# Altium schematic sheet CDU_Logic.SchDoc(CDU_Logic)
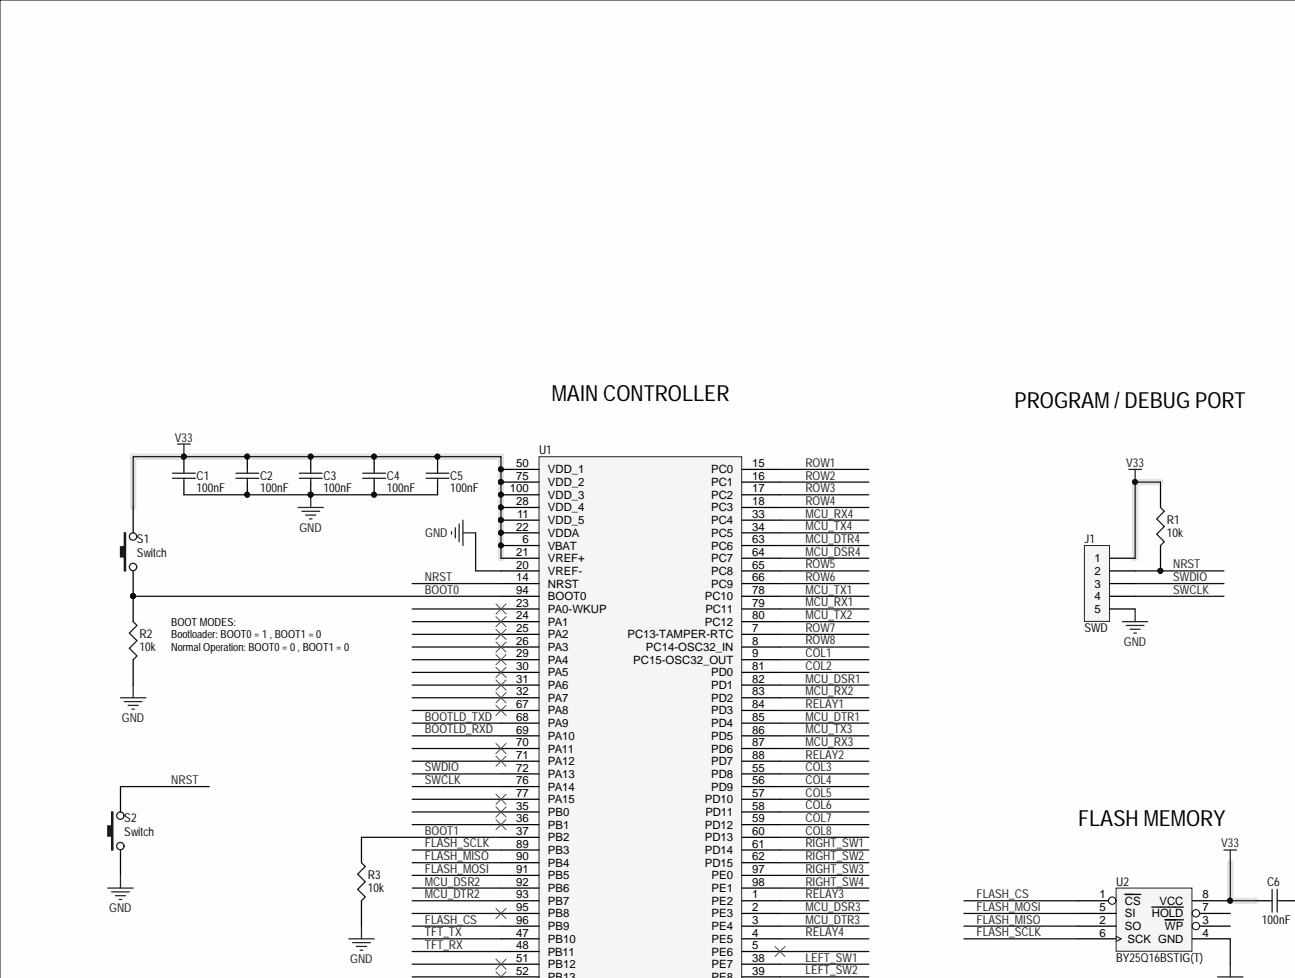
[diagram p0: CDU_Logic.SchDoc, top left]
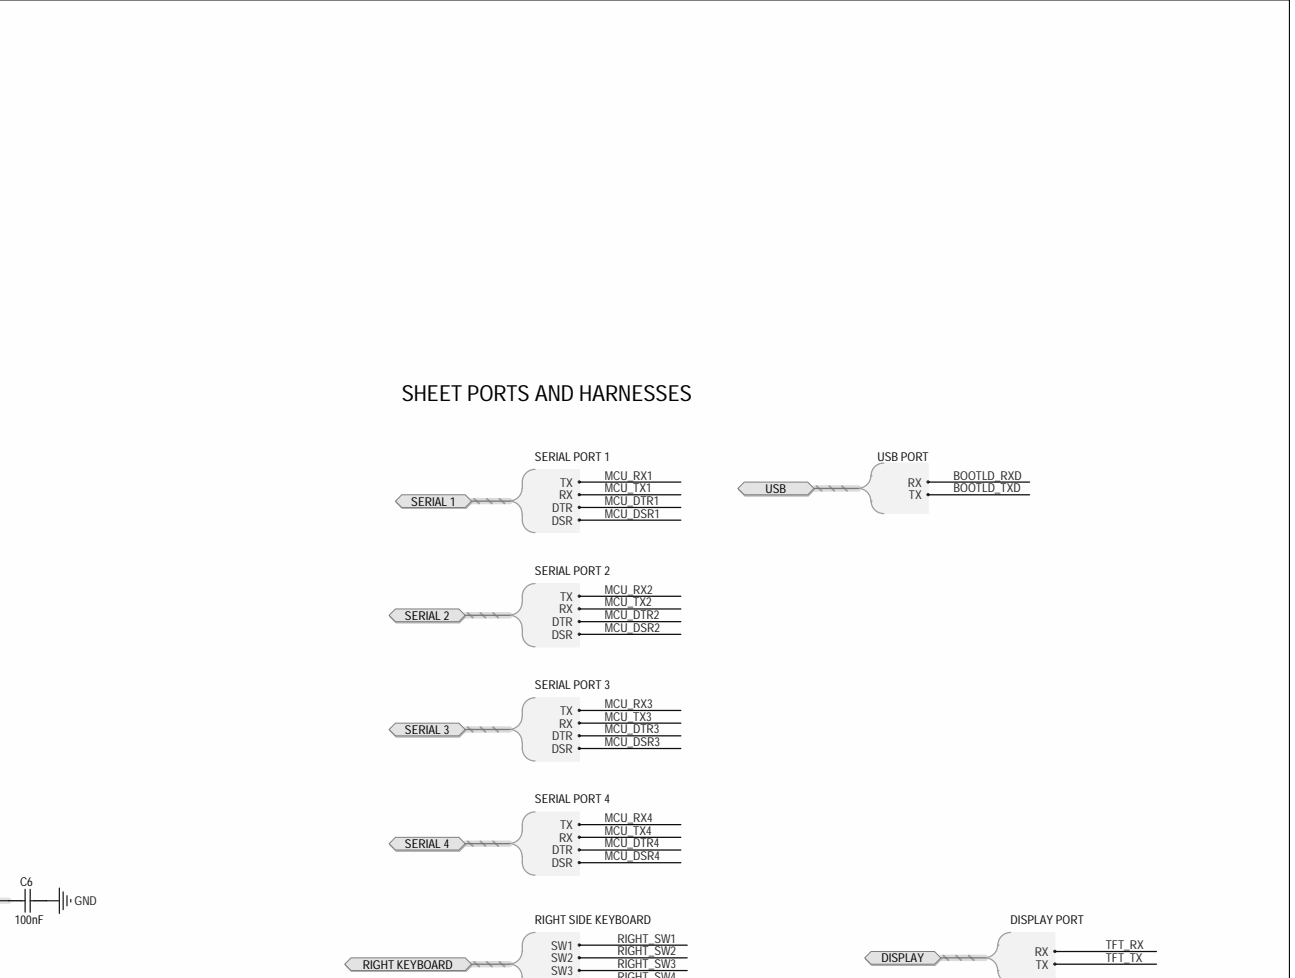
[diagram p1: CDU_Logic.SchDoc, top right]
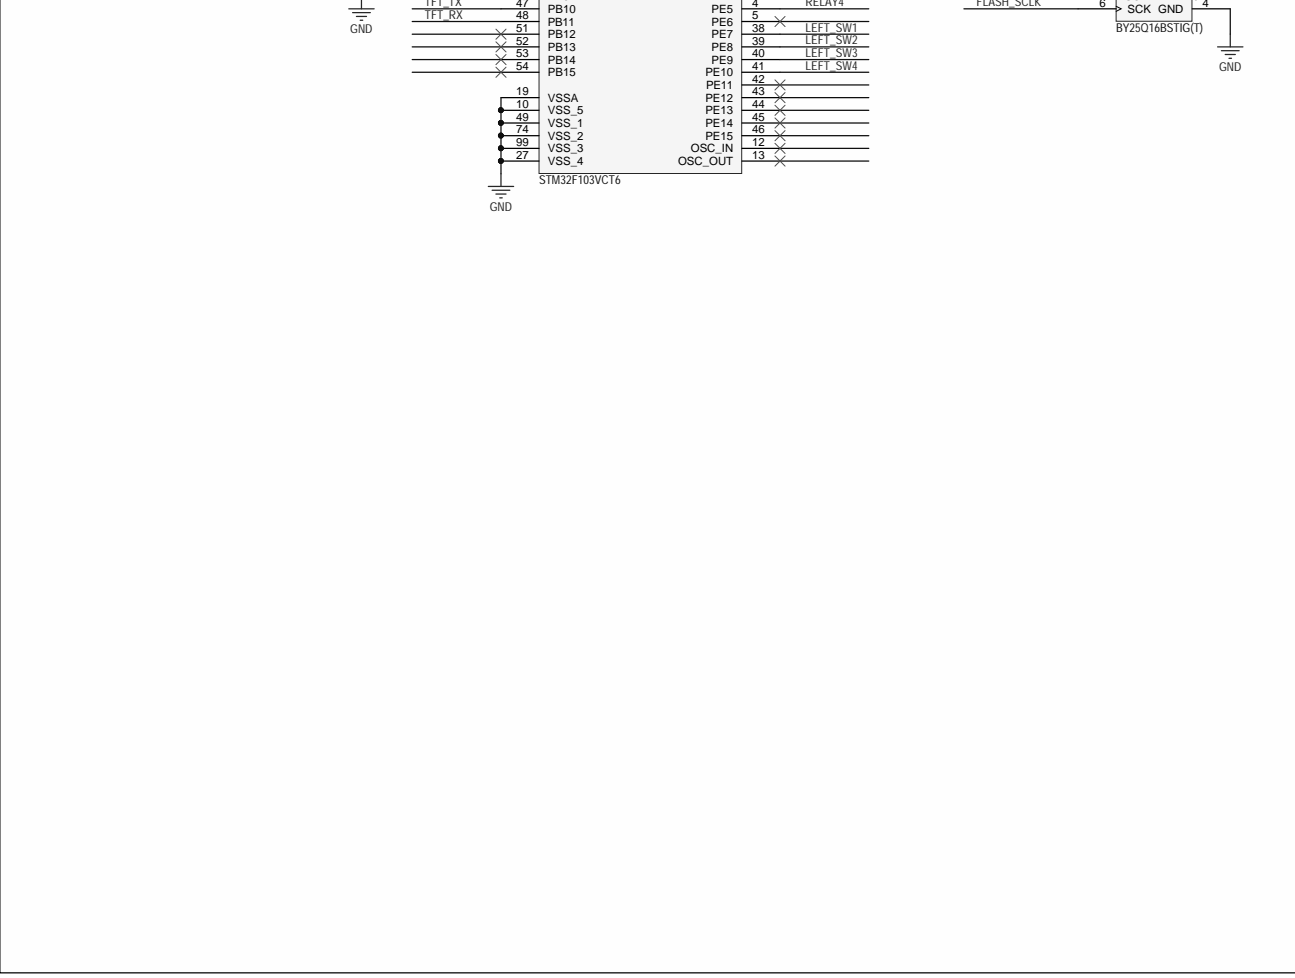
[diagram p2: CDU_Logic.SchDoc, bottom left]
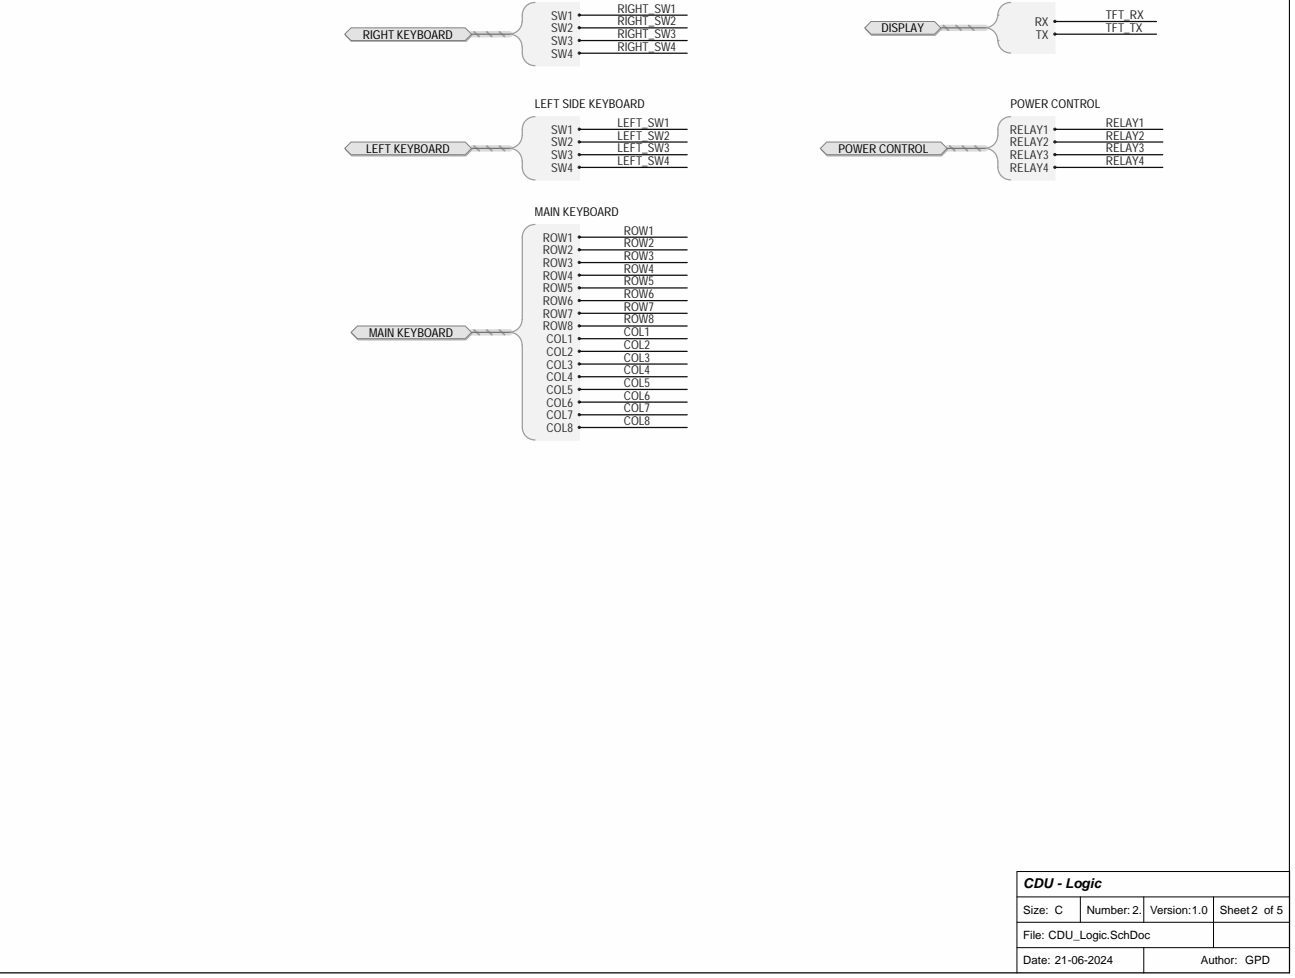
[diagram p3: CDU_Logic.SchDoc, bottom right]

## Parts
C1  100nF  [C0402]
C2  100nF  [C0402]
C3  100nF  [C0402]
C4  100nF  [C0402]
C5  100nF  [C0402]
C6  100nF  [C0402]
J1  SWD  [HDR1X5]
R1  10k  [R0402]
R2  10k  [R0402]
R3  10k  [R0402]
S1  Switch  [SWITCH_A06_RA]
S2  Switch  [SWITCH_A06_RA]
U1  STM32F103VCT6  [F-QFP14X14-G100/N]
U2  BY25Q16BSTIG(T)  [SO-G8]

## Ports
DISPLAY, DISPLAY.RX, DISPLAY.TX, LEFT KEYBOARD, LEFT KEYBOARD.SW1, LEFT KEYBOARD.SW2, LEFT KEYBOARD.SW3, LEFT KEYBOARD.SW4, MAIN KEYBOARD, MAIN KEYBOARD.COL1, MAIN KEYBOARD.COL2, MAIN KEYBOARD.COL3, MAIN KEYBOARD.COL4, MAIN KEYBOARD.COL5, MAIN KEYBOARD.COL6, MAIN KEYBOARD.COL7, MAIN KEYBOARD.COL8, MAIN KEYBOARD.ROW1, MAIN KEYBOARD.ROW2, MAIN KEYBOARD.ROW3, MAIN KEYBOARD.ROW4, MAIN KEYBOARD.ROW5, MAIN KEYBOARD.ROW6, MAIN KEYBOARD.ROW7, MAIN KEYBOARD.ROW8, POWER CONTROL, POWER CONTROL.RELAY1, POWER CONTROL.RELAY2, POWER CONTROL.RELAY3, POWER CONTROL.RELAY4, RIGHT KEYBOARD, RIGHT KEYBOARD.SW1, RIGHT KEYBOARD.SW2, RIGHT KEYBOARD.SW3, RIGHT KEYBOARD.SW4, SERIAL 1, SERIAL 1.DSR, SERIAL 1.DTR, SERIAL 1.RX, SERIAL 1.TX, SERIAL 2, SERIAL 2.DSR, SERIAL 2.DTR, SERIAL 2.RX, SERIAL 2.TX, SERIAL 3, SERIAL 3.DSR, SERIAL 3.DTR, SERIAL 3.RX, SERIAL 3.TX, SERIAL 4, SERIAL 4.DSR, SERIAL 4.DTR, SERIAL 4.RX, SERIAL 4.TX, USB, USB.RX, USB.TX

## Nets
GND: C1.2 C2.2 C3.2 C4.2 C5.2 C6.1 J1.5 R2.2 R3.2 S2.2 U1.10(VSS_5) U1.19(VSSA) U1.20(VREF-) U1.27(VSS_4) U1.49(VSS_1) U1.74(VSS_2) U1.99(VSS_3) U2.4(GND)
V33: C1.1 C2.1 C3.1 C4.1 C5.1 C6.2 J1.1 R1.1 S1.1 U1.6(VBAT) U1.11(VDD_5) U1.21(VREF+) U1.22(VDDA) U1.28(VDD_4) U1.50(VDD_1) U1.75(VDD_2) U1.100(VDD_3) U2.8(VCC)
BOOT0: R2.1 S1.2 U1.94(BOOT0)
BOOT1: R3.1 U1.37(PB2)
BOOTLD_RXD: U1.69(PA10) ; port USB
BOOTLD_TXD: U1.68(PA9) ; port USB
COL1: U1.9(PC15-OSC32_OUT) ; port MAIN KEYBOARD
COL2: U1.81(PD0) ; port MAIN KEYBOARD
COL3: U1.55(PD8) ; port MAIN KEYBOARD
COL4: U1.56(PD9) ; port MAIN KEYBOARD
COL5: U1.57(PD10) ; port MAIN KEYBOARD
COL6: U1.58(PD11) ; port MAIN KEYBOARD
COL7: U1.59(PD12) ; port MAIN KEYBOARD
COL8: U1.60(PD13) ; port MAIN KEYBOARD
FLASH_CS: U1.96(PB9) U2.1(CS)
FLASH_MISO: U1.90(PB4) U2.2(SO)
FLASH_MOSI: U1.91(PB5) U2.5(SI)
FLASH_SCLK: U1.89(PB3) U2.6(SCK)
LEFT_SW1: U1.38(PE7) ; port LEFT KEYBOARD
LEFT_SW2: U1.39(PE8) ; port LEFT KEYBOARD
LEFT_SW3: U1.40(PE9) ; port LEFT KEYBOARD
LEFT_SW4: U1.41(PE10) ; port LEFT KEYBOARD
MCU_DSR1: U1.82(PD1) ; port SERIAL 1
MCU_DSR2: U1.92(PB6) ; port SERIAL 2
MCU_DSR3: U1.2(PE3) ; port SERIAL 3
MCU_DSR4: U1.64(PC7) ; port SERIAL 4
MCU_DTR1: U1.85(PD4) ; port SERIAL 1
MCU_DTR2: U1.93(PB7) ; port SERIAL 2
MCU_DTR3: U1.3(PE4) ; port SERIAL 3
MCU_DTR4: U1.63(PC6) ; port SERIAL 4
MCU_RX1: U1.79(PC11) ; port SERIAL 1
MCU_RX2: U1.83(PD2) ; port SERIAL 2
MCU_RX3: U1.87(PD6) ; port SERIAL 3
MCU_RX4: U1.33(PC4) ; port SERIAL 4
MCU_TX1: U1.78(PC10) ; port SERIAL 1
MCU_TX2: U1.80(PC12) ; port SERIAL 2
MCU_TX3: U1.86(PD5) ; port SERIAL 3
MCU_TX4: U1.34(PC5) ; port SERIAL 4
NRST: J1.2 R1.2 S2.1 U1.14(NRST)
RELAY1: U1.84(PD3) ; port POWER CONTROL
RELAY2: U1.88(PD7) ; port POWER CONTROL
RELAY3: U1.1(PE2) ; port POWER CONTROL
RELAY4: U1.4(PE5) ; port POWER CONTROL
RIGHT_SW1: U1.61(PD14) ; port RIGHT KEYBOARD
RIGHT_SW2: U1.62(PD15) ; port RIGHT KEYBOARD
RIGHT_SW3: U1.97(PE0) ; port RIGHT KEYBOARD
RIGHT_SW4: U1.98(PE1) ; port RIGHT KEYBOARD
ROW1: U1.15(PC0) ; port MAIN KEYBOARD
ROW2: U1.16(PC1) ; port MAIN KEYBOARD
ROW3: U1.17(PC2) ; port MAIN KEYBOARD
ROW4: U1.18(PC3) ; port MAIN KEYBOARD
ROW5: U1.65(PC8) ; port MAIN KEYBOARD
ROW6: U1.66(PC9) ; port MAIN KEYBOARD
ROW7: U1.7(PC13-TAMPER-RTC) ; port MAIN KEYBOARD
ROW8: U1.8(PC14-OSC32_IN) ; port MAIN KEYBOARD
SWCLK: J1.4 U1.76(PA14)
SWDIO: J1.3 U1.72(PA13)
TFT_RX: U1.48(PB11) ; port DISPLAY
TFT_TX: U1.47(PB10) ; port DISPLAY

Not connected: U1 5(PE6) 12(OSC_IN) 13(OSC_OUT) 23(PA0-WKUP) 24(PA1) 25(PA2) 26(PA3) 29(PA4) 30(PA5) 31(PA6) 32(PA7) 35(PB0) 36(PB1) 42(PE11) 43(PE12) 44(PE13) 45(PE14) 46(PE15) 51(PB12) 52(PB13) 53(PB14) 54(PB15) 67(PA8) 70(PA11) 71(PA12) 77(PA15) 95(PB8); U2 3(WP) 7(HOLD)

## Library data of the parts
C1-C6 = CAP: 100nF ±10% 16V X7R 0402 Multilayer Ceramic Capacitors MLCC - SMD/SMT RoHS; Manufacturer Part Number: C0402C104K4RAC-TU; Manufacturer: KEMET; Value: 100nF; Supplier Part Number 3: 399-C0402C104K4RAC7867DKR-ND; Supplier Part Number 2: 8015145; Supplier Part Number 1: 80-C0402C104K4R; Supplier 3: Digikey; Supplier 2: Rs; Supplier 1: Mouser; Library Name: Miscellaneous Devices.SchLib; Component Kind: Standard
J1 = CON5: Free pads - do not populate; Value: SWD; Supplier Part Number 3: N/A; Supplier Part Number 2: N/A; Supplier Part Number 1: N/A; Supplier 3: N/A; Supplier 2: N/A; Supplier 1: N/A; Manufacturer Part Number: N/A; Manufacturer: N/A; Library Name: Miscellaneous Devices.SchLib; Component Kind: Standard
R1-R3 = RES1: SMD Chip Resistor, 10 kOhm, ± 5%, 63 mW, 0402 [1005 Metric], Thick Film, General Purpose; Manufacturer Part Number: CRCW040210K0JNED; Manufacturer: Vishay Dale; Value: 10k; Supplier Part Number 3: 541-10KJDKR-ND; Supplier Part Number 2: C191984; Supplier Part Number 1: 71-CRCW0402J-10K-E3; Supplier 3: Digikey; Supplier 2: LCSC; Supplier 1: Mouser; Library Name: Miscellaneous Devices.SchLib; Component Kind: Standard
S1 S2 = SW-PB: Switch Tactile N.O. SPST Round Button PC Pins 0.05A 12VDC 1.57N Thru-Hole Automotive Bulk; Manufacturer Part Number: SKHHLRA010; Manufacturer: Alps Electric; Value: Switch; Supplier Part Number 3: C383800; Supplier Part Number 2: 688-SKHHLR; Supplier Part Number 1: 4809-SKHHLRA010-ND; Supplier 3: LCSC; Supplier 2: Mouser; Supplier 1: Digikey; Library Name: Miscellaneous Devices.SchLib; Component Kind: Standard
U1 = STM32F103VCT6: MCU 32-bit STM32F1 ARM Cortex M3 RISC 256KB Flash 2.5V/3.3V 100-Pin LQFP Tray; Manufacturer: STMicroelectronics; Value: STM32F103VCT6; Supplier Part Number 3: 1624139; Supplier Part Number 2: 511-STM32F103VCT6; Supplier Part Number 1: C8313; Supplier 3: Farnell; Supplier 2: Mouser; Supplier 1: LCSC; Manufacturer Part Number: STM32F103VCT6; Library Name: STM32.SchLib; ComponentLink1URL: https://datasheet.ciiva.com/8054/1831497-8054965.pdf; ComponentLink1Description: Datasheet; Component Kind: Standard
U2 = AT25F2048: SOP-8 NOR FLASH ROHS; Manufacturer: BOYAMICRO; Value: BY25Q16BSTIG(T); Supplier Part Number 3: C382744; Supplier Part Number 2: C382744; Supplier Part Number 1: C382744; Supplier 3: LCSC; Supplier 2: LCSC; Supplier 1: LCSC; Manufacturer Part Number: BY25Q16BSTIG(T); Library Name: ICs.SchLib; ComponentLink1URL: https://datasheet.lcsc.com/lcsc/1912111437_BOYAMICRO-BY25Q16BSTIG-T_C382744.pdf?_gl=1*72e4c3*_gcl_au*MTUzNDU1MTE0Mi4xNzE0NDQxNzc1*_ga*NDgwNzM5Njg2LjE3MTQ0NDE3Nzc.*_ga_98M84MKSZH*MTcxNTI5MzI1My4xNS4xLjE3MTUyOTMyNTQuNTkuMC4w; ComponentLink1Description: Datasheet; Component Kind: Standard
